# Altium schematic sheet Sheet1.SchDoc
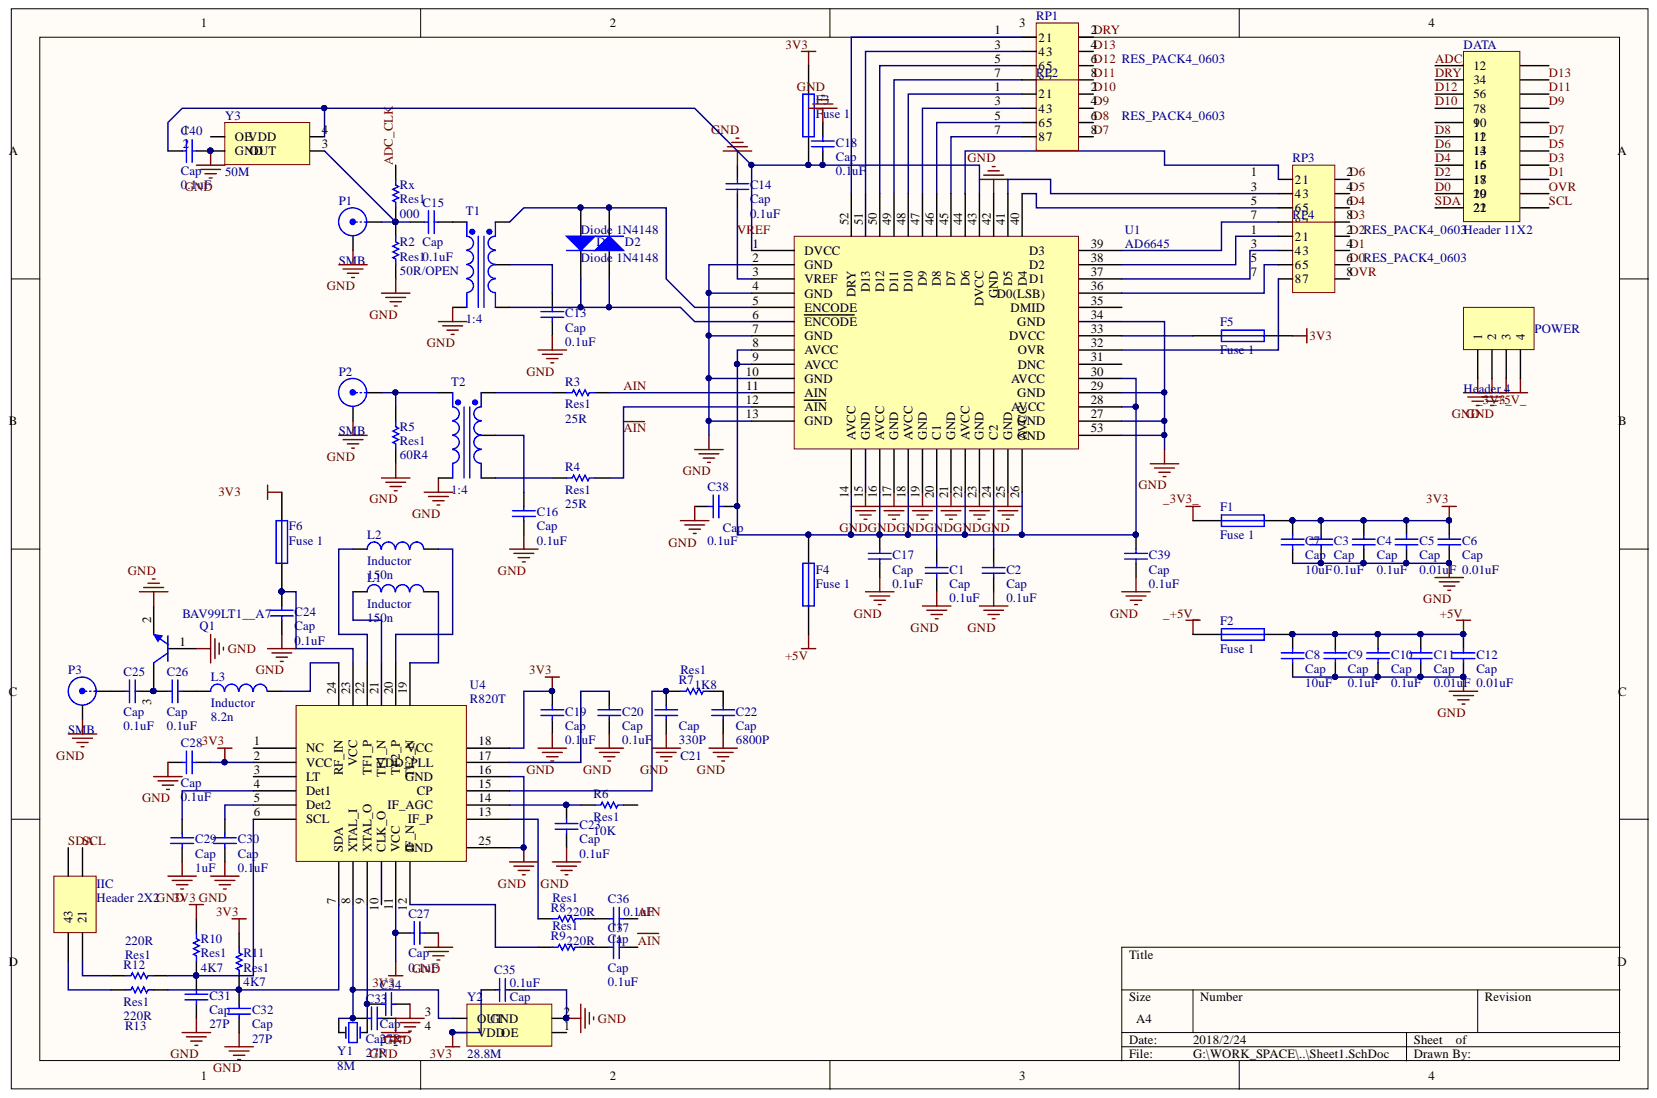
[diagram p0: Sheet1.SchDoc]

## Parts
C1  Cap  value 0.1uF  [6-0805_N]
C2  Cap  value 0.1uF  [6-0805_N]
C3  Cap  value 0.1uF  [6-0805_N]
C4  Cap  value 0.1uF  [6-0805_N]
C5  Cap  value 0.01uF  [6-0805_N]
C6  Cap  value 0.01uF  [6-0805_N]
C7  Cap  value 10uF  [CAP-3216]
C8  Cap  value 10uF  [CAP-3216]
C9  Cap  value 0.1uF  [6-0805_N]
C10  Cap  value 0.1uF  [6-0805_N]
C11  Cap  value 0.01uF  [6-0805_N]
C12  Cap  value 0.01uF  [6-0805_N]
C13  Cap  value 0.1uF  [6-0805_N]
C14  Cap  value 0.1uF  [6-0805_N]
C15  Cap  value 0.1uF  [6-0805_N]
C16  Cap  value 0.1uF  [6-0805_N]
C17  Cap  value 0.1uF  [6-0805_N]
C18  Cap  value 0.1uF  [6-0805_N]
C19  Cap  value 0.1uF  [6-0805_N]
C20  Cap  value 0.1uF  [6-0805_N]
C21  Cap  value 330P  [6-0805_N]
C22  Cap  value 6800P  [6-0805_N]
C23  Cap  value 0.1uF  [6-0805_N]
C24  Cap  value 0.1uF  [6-0805_N]
C25  Cap  value 0.1uF  [6-0805_N]
C26  Cap  value 0.1uF  [6-0805_N]
C27  Cap  value 0.1uF  [6-0805_N]
C28  Cap  value 0.1uF  [6-0805_N]
C29  Cap  value 1uF  [6-0805_N]
C30  Cap  value 0.1uF  [6-0805_N]
C31  Cap  value 27P  [6-0805_N]
C32  Cap  value 27P  [6-0805_N]
C33  Cap  value 27P  [6-0805_N]
C34  Cap  value 27P  [6-0805_N]
C35  Cap  value 0.1uF  [6-0805_N]
C36  Cap  value 0.1uF  [6-0805_N]
C37  Cap  value 0.1uF  [6-0805_N]
C38  Cap  value 0.1uF  [6-0805_N]
C39  Cap  value 0.1uF  [6-0805_N]
C40  Cap  value 0.1uF  [6-0805_N]
D1  Diode 1N4148  [6-0805_N]
D2  Diode 1N4148  [6-0805_N]
DATA  Header 11X2  [HDR2X11]
F1  Fuse 1  [6-0805_N]
F2  Fuse 1  [6-0805_N]
F3  Fuse 1  [6-0805_N]
F4  Fuse 1  [6-0805_N]
F5  Fuse 1  [6-0805_N]
F6  Fuse 1  [6-0805_N]
IIC  Header 2X2  [HDR2X2]
L1  Inductor  value 150n  [6-0805_N]
L2  Inductor  value 150n  [6-0805_N]
L3  Inductor  value 8.2n  [6-0805_N]
P1  SMB  [SMB_V-RJ45]
P2  SMB  [SMB_V-RJ45]
P3  SMB  [SMB_V-RJ45]
POWER  Header 4  [HDR1X4]
Q1  BAV99LT1__A7  [SOT23-3]
R2  Res1  value 50R/OPEN  [6-0805_N]
R3  Res1  value 25R  [6-0805_N]
R4  Res1  value 25R  [6-0805_N]
R5  Res1  value 60R4  [6-0805_N]
R6  Res1  value 10K  [6-0805_N]
R7  Res1  value 1K8  [6-0805_N]
R8  Res1  value 220R  [6-0805_N]
R9  Res1  value 220R  [6-0805_N]
R10  Res1  value 4K7  [6-0805_N]
R11  Res1  value 4K7  [6-0805_N]
R12  Res1  value 220R  [6-0805_N]
R13  Res1  value 220R  [6-0805_N]
RP1  RES_PACK4_0603  [8P4R_0603]
RP2  RES_PACK4_0603  [8P4R_0603]
RP3  RES_PACK4_0603  [8P4R_0603]
RP4  RES_PACK4_0603  [8P4R_0603]
Rx  Res1  value 000  [6-0805_N]
T1  1:4  [T2]
T2  1:4  [TC4-1W+]
U1  AD6645  [AD6645]
U4  R820T  [R820T]
Y1  value 8M  [X-HC-49S]  (hidden comment: XTAL)
Y2  value 28.8M  [XTAL4PIN]  (hidden comment: XATLS)
Y3  value 50M  [XTAL4PIN]  (hidden comment: XATLS)

## Nets
3V3: C3.2 C4.2 C5.2 C6.2 C7.2 C19.1 C27.1 C28.2 C35.2 F1.2 F3.2 F5.2 F6.1 R10.2 R11.2 U4.2(VCC) U4.11(VCC) U4.18 Y2.4(VDD)
+5V: C8.2 C9.2 C10.2 C11.2 C12.2 F2.2 F4.1
_3V3_: F1.1 POWER.3
_+5V_: F2.1 POWER.4
GND: C1.1 C2.1 C3.1 C4.1 C5.1 C6.1 C7.1 C8.1 C9.1 C10.1 C11.1 C12.1 C13.1 C14.2 C16.1 C17.2 C18.2 C19.2 C20.2 C21.2 C22.2 C23.2 C24.2 C27.2 C28.1 C29.1 C30.1 C31.1 C32.1 C33.1 C34.1 C35.1 C38.2 C39.2 C40.1 P1.2 P2.2 P3.2 POWER.1 POWER.2 Q1.1 Q1.2 R2.1 R5.1 T1.2 T2.2 U1.2(GND) U1.4(GND) U1.7(GND) U1.10(GND) U1.13(GND) U1.15(GND) U1.17(GND) U1.19(GND) U1.21(GND) U1.23(GND) U1.25(GND) U1.27 U1.29 U1.34 U1.42 U1.53 U4.16 U4.25 Y2.2 Y3.2(GND)
~{AIN}: C37.1 R4.2 U1.12(AIN)
ADC_CLK: DATA.1 Rx.2
AIN: C36.1 R3.2 U1.11(AIN)
D0: DATA.19 RP4.6
D1: DATA.18 RP4.4
D2: DATA.17 RP4.2
D3: DATA.16 RP3.8
D4: DATA.15 RP3.6
D5: DATA.14 RP3.4
D6: DATA.13 RP3.2
D7: DATA.12 RP2.8
D8: DATA.11 RP2.6
D9: DATA.8 RP2.4
D10: DATA.7 RP2.2
D11: DATA.6 RP1.8
D12: DATA.5 RP1.6
D13: DATA.4 RP1.4
DRY: DATA.3 RP1.2
OVR: DATA.20 RP4.8
SCL: DATA.22 IIC.1
SDA: DATA.21 IIC.3
VREF: C14.1 U1.3(VREF)
NetC1_2: C1.2 U1.20(C1)
NetC2_2: C2.2 U1.24(C2)
NetC13_2: C13.2 T1.4
NetC15_1: C15.1 T1.1
NetC15_2: C15.2 P1.1 R2.2 Rx.1 Y3.3
NetC16_2: C16.2 T2.4
NetC17_1: C17.1 C38.1 C39.1 F4.2 U1.8(AVCC) U1.9(AVCC) U1.14(AVCC) U1.16(AVCC) U1.18(AVCC) U1.22(AVCC) U1.26(AVCC) U1.28 U1.30
NetC18_1: C18.1 C40.2 F3.1 U1.1(DVCC) U1.43 Y3.4
NetC20_1: C20.1 U4.17
NetC21_1: C21.1 R7.1 U4.15
NetC22_1: C22.1 R7.2
NetC23_1: C23.1 R6.1 U4.14
NetC24_1: C24.1 F6.2 U4.23
NetC25_1: C25.1 P3.1
NetC25_2: C25.2 C26.1 Q1.3
NetC26_2: C26.2 L3.1
NetC29_2: C29.2 U4.4(Det1)
NetC30_2: C30.2 U4.5(Det2)
NetC31_2: C31.2 R10.1 R12.1 U4.6(SCL)
NetC32_2: C32.2 R11.1 R13.1 U4.7(SDA)
NetC33_2: C33.2 U4.8(XTAL_I) Y1.2 Y2.3(OUT)
NetC34_2: C34.2 U4.9(XTAL_O) Y1.1
NetC36_2: C36.2 R8.2
NetC37_2: C37.2 R9.2
NetD1_1: D1.1 D2.2 T1.3 U1.5(ENCODE)
NetD1_2: D1.2 D2.1 T1.5 U1.6(ENCODE)
NetF5_1: F5.1 U1.33
NetIIC_2: IIC.2(2_1) R12.2
NetIIC_4: IIC.4(4_3) R13.2
NetL1_1: L1.1 U4.21
NetL1_2: L1.2 U4.19
NetL2_1: L2.1 U4.22
NetL2_2: L2.2 U4.20
NetL3_2: L3.2 U4.24
NetP2_1: P2.1 R5.2 T2.1
NetR3_1: R3.1 T2.3
NetR4_1: R4.1 T2.5
NetR8_1: R8.1 U4.13
NetR9_1: R9.1 U4.12(IF_N)
NetRP1_1: RP1.1(2_1) U1.52
NetRP1_3: RP1.3(4_3) U1.51
NetRP1_5: RP1.5(6_5) U1.50
NetRP1_7: RP1.7(8_7) U1.49
NetRP2_1: RP2.1(2_1) U1.48
NetRP2_3: RP2.3(4_3) U1.47
NetRP2_5: RP2.5(6_5) U1.46
NetRP2_7: RP2.7(8_7) U1.45
NetRP3_1: RP3.1(2_1) U1.44
NetRP3_3: RP3.3(4_3) U1.41
NetRP3_5: RP3.5(6_5) U1.40
NetRP3_7: RP3.7(8_7) U1.39
NetRP4_1: RP4.1(2_1) U1.38
NetRP4_3: RP4.3(4_3) U1.37
NetRP4_5: RP4.5(6_5) U1.36
NetRP4_7: RP4.7(8_7) U1.32

Not connected: DATA 2 9 10; R6 2; U1 31 35; U4 1(NC) 3(LT) 10(CLK_O); Y2 1; Y3 1(OE)

## Library data of the parts
C1-C14 C16-C24 C28-C33 C35-C40 = Cap: Capacitor; Component Kind: Standard; LatestRevisionDate: 17-Jul-2002; LatestRevisionNote: Re-released for DXP Platform.; Library Name: Miscellaneous Devices.IntLib; PackageDescription: Radial Cap, Thru-Hole; 2 Leads; 0.3 in Pin Spacing; PackageReference: RAD-0.3; Published: 8-Jun-2000; Signal Integrity: Cap; Simulation: CAP; Publisher: Altium Limited
C15 C25-C27 = Cap: Capacitor; Value: 0.1uF; Component Kind: Standard; LatestRevisionDate: 17-Jul-2002; LatestRevisionNote: Re-released for DXP Platform.; Library Name: Miscellaneous Devices.IntLib; PackageDescription: Radial Cap, Thru-Hole; 2 Leads; 0.3 in Pin Spacing; PackageReference: RAD-0.3; Published: 8-Jun-2000; Signal Integrity: Cap; Simulation: CAP; Publisher: Altium Limited
C34 = Cap: Capacitor; Value: 27P; Component Kind: Standard; LatestRevisionDate: 17-Jul-2002; LatestRevisionNote: Re-released for DXP Platform.; Library Name: Miscellaneous Devices.IntLib; PackageDescription: Radial Cap, Thru-Hole; 2 Leads; 0.3 in Pin Spacing; PackageReference: RAD-0.3; Published: 8-Jun-2000; Signal Integrity: Cap; Simulation: CAP; Publisher: Altium Limited
D1 D2 = Diode 1N4148: High Conductance Fast Diode; Code_JEDEC: DO-204-AH; Component Kind: Standard; ComponentLink1Description: Manufacturer Link; ComponentLink1URL: http://www.fairchildsemi.com/; ComponentLink2Description: Datasheet; ComponentLink2URL: http://www.fairchildsemi.com/ds/1N%2F1N4148.pdf; DatasheetVersion: 1997; LatestRevisionDate: 17-Jul-2002; LatestRevisionNote: Re-released for DXP Platform.; Library Name: Miscellaneous Devices.IntLib; PackageDescription: Diode, Axial; Body 3.8 x 1.9 mm (LxDia. max), Lead Dia. 0.53 mm (max); PackageReference: DO-35; Published: 8-Jun-2000; Signal Integrity: 1N4148; Simulation: 1N4148; Publisher: Altium Limited
DATA = Header 11X2: Header, 11-Pin, Dual row; Component Kind: Standard; LatestRevisionDate: 17-Jul-2002; LatestRevisionNote: Re-released for DXP Platform.; Library Name: Miscellaneous Connectors.IntLib; Signal Integrity: Connector; Publisher: Altium Limited
F1-F6 = Fuse 1: Fuse; Component Kind: Standard; LatestRevisionDate: 17-Jul-2002; LatestRevisionNote: Re-released for DXP Platform.; Library Name: Miscellaneous Devices.IntLib; PackageDescription: Thru-Hole; 2 Leads; PackageReference: PIN-W2/E2.8; Published: 8-Jun-2000; Simulation: FUSE; Publisher: Altium Limited
IIC = Header 2X2: Header, 2-Pin, Dual row; Component Kind: Standard; LatestRevisionDate: 17-Jul-2002; LatestRevisionNote: Re-released for DXP Platform.; Library Name: Miscellaneous Connectors.IntLib; Signal Integrity: Connector; Publisher: Altium Limited
L1 L3 = Inductor: Code_IEC: 1005; Code_JEITA: 0402; Component Kind: Standard; Description: Inductor; LatestRevisionDate: 29-May-2009; LatestRevisionNote: IPC-7351 Footprint Added.; Library Name: Miscellaneous Devices.IntLib; PackageDescription: Chip Inductor; PackageReference: 0402-A; Published: 8-Jun-2000; Signal Integrity: Inductor; Simulation: INDUCTOR; Publisher: Altium Limited
L2 = Inductor: Code_IEC: 1005; Value: 150n; Code_JEITA: 0402; Component Kind: Standard; Description: Inductor; LatestRevisionDate: 29-May-2009; LatestRevisionNote: IPC-7351 Footprint Added.; Library Name: Miscellaneous Devices.IntLib; PackageDescription: Chip Inductor; PackageReference: 0402-A; Published: 8-Jun-2000; Signal Integrity: Inductor; Simulation: INDUCTOR; Publisher: Altium Limited
P1-P3 = SMB: SMB Straight Connector; Component Kind: Standard; LatestRevisionDate: 17-Jul-2002; LatestRevisionNote: Re-released for DXP Platform.; Library Name: Miscellaneous Connectors.IntLib; Signal Integrity: Connector; Publisher: Altium Limited
POWER = Header 4: Header, 4-Pin; Component Kind: Standard; LatestRevisionDate: 17-Jul-2002; LatestRevisionNote: Re-released for DXP Platform.; Library Name: Miscellaneous Connectors.IntLib; Signal Integrity: Connector; Publisher: Altium Limited
Q1 = NPN1: 贴片三极管; Code_JEDEC: TO-92A; Component Kind: Standard; ComponentLink1Description: Manufacturer Link; ComponentLink1URL: http://www.fairchildsemi.com/; ComponentLink2Description: Datasheet; ComponentLink2URL: http://www.fairchildsemi.com/ds/2N%2F2N3904.pdf; DatasheetDocument: 1997; LatestRevisionDate: 15-Jan-2003; LatestRevisionNote: PCB Footprint 'BCY-W3/D4.7' replaced; PackageDocument: Sep-1998; PackageReference: TO-92A; Published: 8-Jun-2000; Library Name: fszhang.SchLib; Publisher: Altium Limited
R2 R3 R5 R7-R13 Rx = Res1: Resistor; Component Kind: Standard; LatestRevisionDate: 17-Jul-2002; LatestRevisionNote: Re-released for DXP Platform.; Library Name: Miscellaneous Devices.IntLib; PackageDescription: Axial Device, Thru-Hole; 2 Leads; 0.3 in Pin Spacing; PackageReference: AXIAL-0.3; Published: 8-Jun-2000; Signal Integrity: Res; Simulation: RESISTOR; Publisher: Altium Limited
R4 = Res1: Resistor; Value: 25R; Component Kind: Standard; LatestRevisionDate: 17-Jul-2002; LatestRevisionNote: Re-released for DXP Platform.; Library Name: Miscellaneous Devices.IntLib; PackageDescription: Axial Device, Thru-Hole; 2 Leads; 0.3 in Pin Spacing; PackageReference: AXIAL-0.3; Published: 8-Jun-2000; Signal Integrity: Res; Simulation: RESISTOR; Publisher: Altium Limited
R6 = Res1: Resistor; Value: 10K; Component Kind: Standard; LatestRevisionDate: 17-Jul-2002; LatestRevisionNote: Re-released for DXP Platform.; Library Name: Miscellaneous Devices.IntLib; PackageDescription: Axial Device, Thru-Hole; 2 Leads; 0.3 in Pin Spacing; PackageReference: AXIAL-0.3; Published: 8-Jun-2000; Signal Integrity: Res; Simulation: RESISTOR; Publisher: Altium Limited
T1 T2 = Trans CT Ideal: Center-Tapped Transformer (Ideal); Component Kind: Standard; LatestRevisionDate: 17-Jul-2002; LatestRevisionNote: Re-released for DXP Platform.; Library Name: Miscellaneous Devices.IntLib; PackageDescription: Transformer; 5 Leads; PackageReference: TRF_5; Published: 8-Jun-2000; Simulation: IDEALTRANSCT; Publisher: Altium Limited
Y1 = XTAL: 晶振; Component Kind: Standard; LatestRevisionDate: 17-Jul-2002; LatestRevisionNote: Re-released for DXP Platform.; PackageReference: R38; Published: 8-Jun-2000; Library Name: fszhang.SchLib; Publisher: Altium Limited
Y2 Y3 = XATLS: 贴片有源晶振; Component Kind: Standard; Library Name: fszhang.SchLib
RP1-RP4 = RES_PACK4_0603: Component Kind: Standard; Library Name: RES_PACK4_0603.SchLib
U1 = AD6645: Component Kind: Standard; Library Name: AD6645.SchLib
U4 = R820T: Component Kind: Standard; Library Name: R820T.SchLib
